# Altium schematic sheet PAGE04 FPGA CLOCK.SchDoc
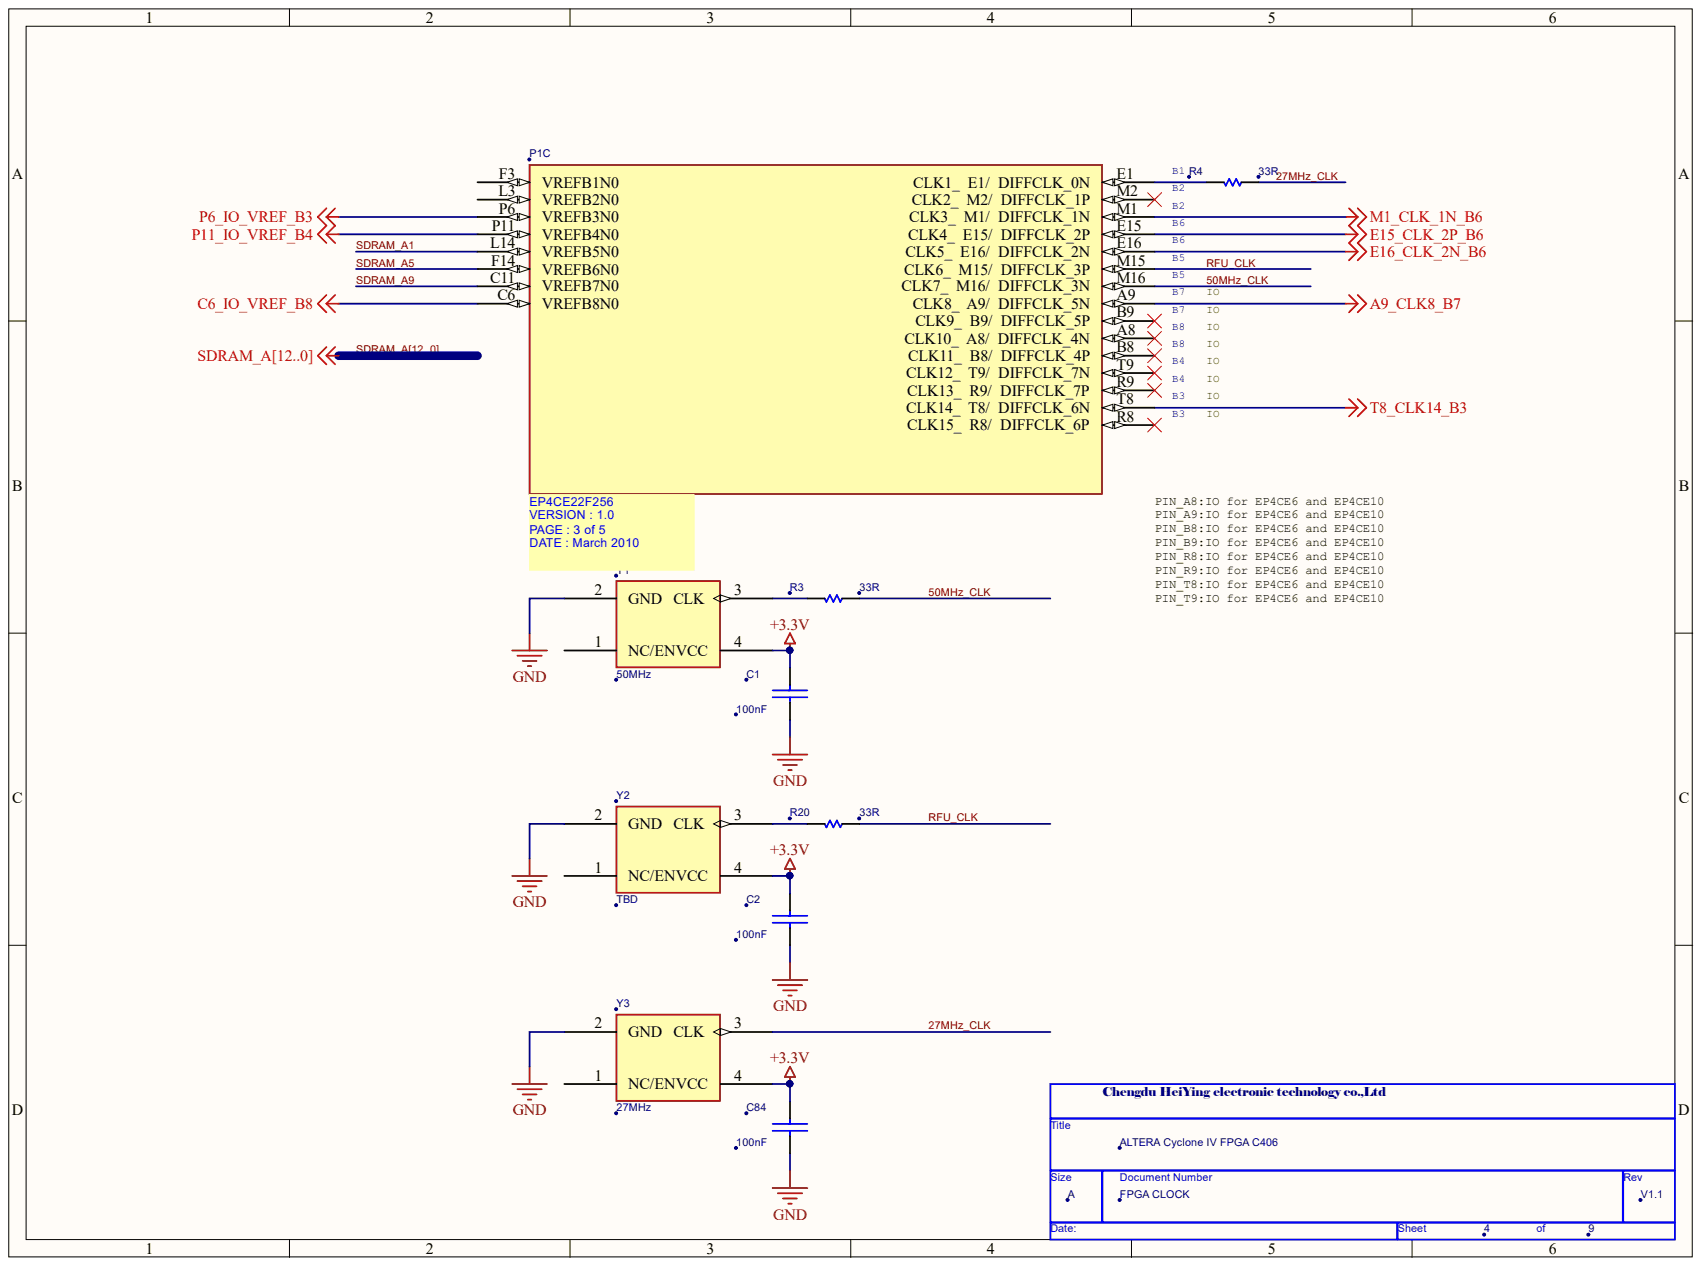
[diagram p0: PAGE04 FPGA CLOCK.SchDoc]

## Parts
C1  100nF  [C0402]
C2  100nF  [C0402]
C84  100nF  [C0402]
P1  EP4CE22F256  [fbga256_opt1]  (part C)
R3  33R  [R0402]
R4  33R  [R0402]
R20  33R  [R0402]
Y1  50MHz  [OSC3225]
Y2  TBD  [OSC3225]
Y3  27MHz  [OSC3225]

## Nets
+3.3V: C1.1 C2.1 C84.1 Y1.4(VCC) Y2.4(VCC) Y3.4(VCC)
A9_CLK8_B7: P1.A9(DIFFCLK_5N)
C6_IO_VREF_B8: P1.C6(VREFB8N0)
E15_CLK_2P_B6: P1.E15(DIFFCLK_2P)
E16_CLK_2N_B6: P1.E16(DIFFCLK_2N)
GND: C1.2 C2.2 C84.2 Y1.2(GND) Y2.2(GND) Y3.2(GND)
M1_CLK_1N_B6: P1.M1(DIFFCLK_1N)
P6_IO_VREF_B3: P1.P6(VREFB3N0)
P11_IO_VREF_B4: P1.P11(VREFB4N0)
T8_CLK14_B3: P1.T8(DIFFCLK_6N)
27MHZ_CLK: R4.1 Y3.3(CLK)
50MHZ_CLK: P1.M16(DIFFCLK_3N) R3.1
RFU_CLK: P1.M15(DIFFCLK_3P) R20.1
SDRAM_A1: P1.L14(VREFB5N0)  [also labelled SDRAM_A]
SDRAM_A5: P1.F14(VREFB6N0)  [also labelled SDRAM_A]
SDRAM_A9: P1.C11(VREFB7N0)  [also labelled SDRAM_A]
NetP1_E1: P1.E1(DIFFCLK_0N) R4.2
NetR3_2: R3.2 Y1.3(CLK)
NetR20_2: R20.2 Y2.3(CLK)
SDRAM_A0:  [also labelled SDRAM_A]
SDRAM_A2:  [also labelled SDRAM_A]
SDRAM_A3:  [also labelled SDRAM_A]
SDRAM_A4:  [also labelled SDRAM_A]
SDRAM_A6:  [also labelled SDRAM_A]
SDRAM_A7:  [also labelled SDRAM_A]
SDRAM_A8:  [also labelled SDRAM_A]
SDRAM_A10:  [also labelled SDRAM_A]
SDRAM_A11:  [also labelled SDRAM_A]
SDRAM_A12:  [also labelled SDRAM_A]

Not connected: P1 A8(DIFFCLK_4N) B8(DIFFCLK_4P) B9(DIFFCLK_5P) F3(VREFB1N0) L3(VREFB2N0) M2(DIFFCLK_1P) R8(DIFFCLK_6P) R9(DIFFCLK_7P) T9(DIFFCLK_7N); Y1 1(NC/EN); Y2 1(NC/EN); Y3 1(NC/EN)

## Library data of the parts
C1 C2 C84 = CAP_0: CAP CER .1UF 16V X7R 0402; MANUFACTURER: MURATA; MANUFACTURER P/N: GRM155R71C104KA88D; ROOM: PHY&FPGA; Component Kind: Standard; DIELECTRIC: X7R; DISTRIBUTOR: DIGIKEY; DISTRIBUTOR P/N: 490-3261-1-ND; PRICE: 0.00300
P1 = EP4CE22F256: SPLIT_INST: TRUE; SWAP_INFO: (S1+S2+S3+S4+S5); Component Kind: Standard
R3 R4 R20 = SMALL R1: Component Kind: Standard
Y1-Y3 = OSCILLATOR_0: ROOM: PHY&FPGA; Component Kind: Standard
